# Altium schematic sheet 08_SOM_USB_CARD.SchDoc(08_SOM_USB_CARD)
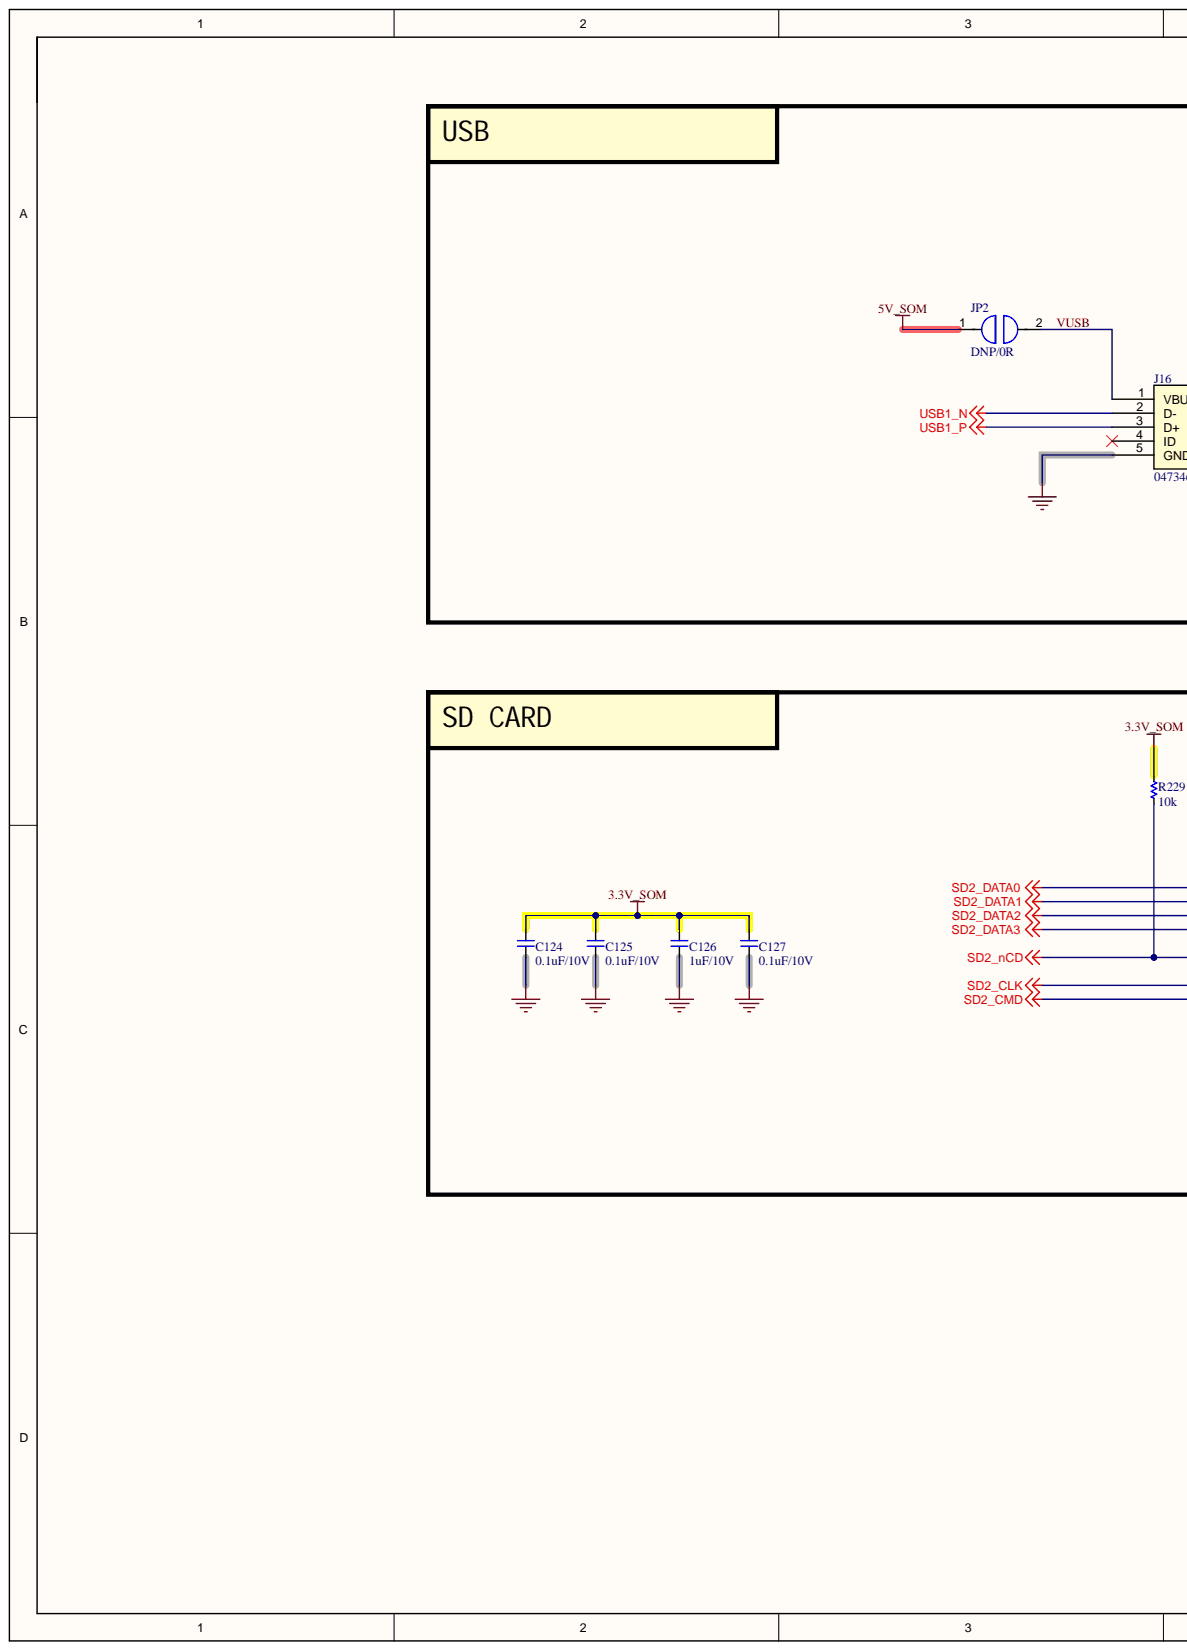
[diagram p0: 08_SOM_USB_CARD.SchDoc, left]
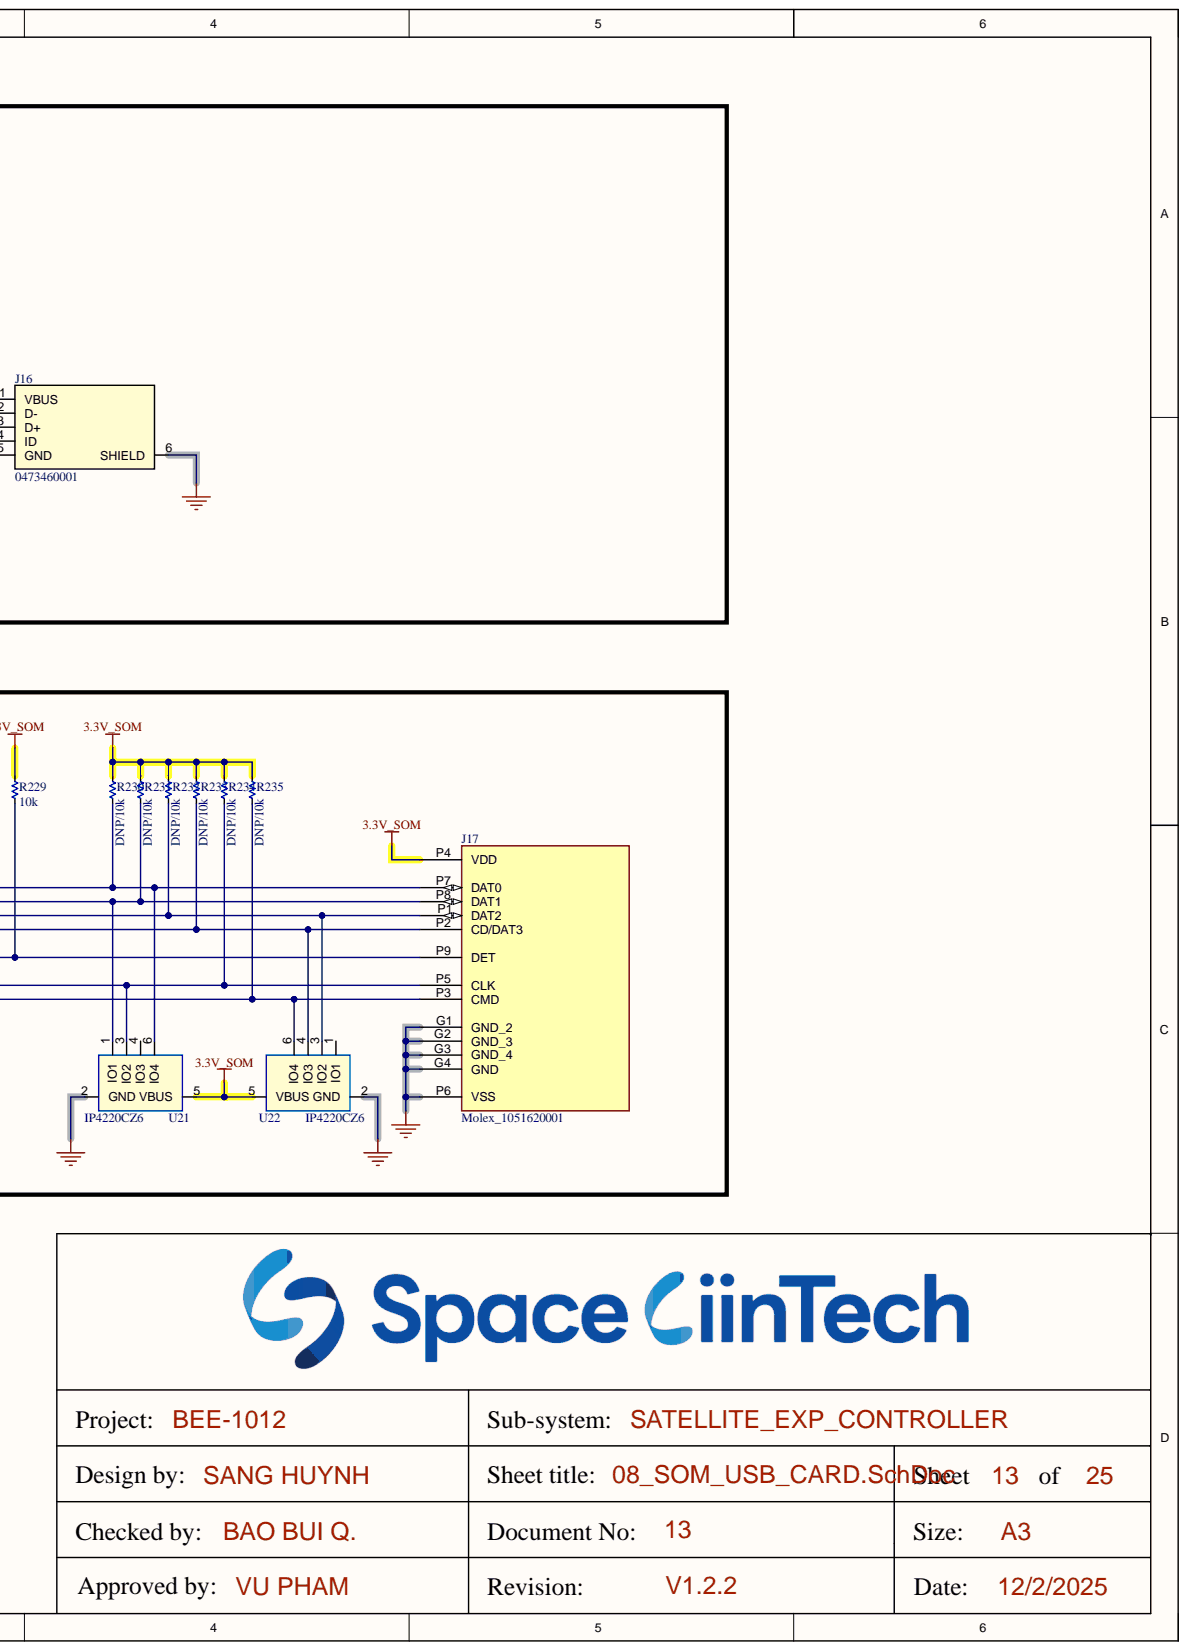
[diagram p1: 08_SOM_USB_CARD.SchDoc, right]

## Parts
C124  0.1uF/10V  [CAP_C_1005X60]
C125  0.1uF/10V  [CAP_C_1005X60]
C126  1uF/10V  [CAP_C_1005X60]
C127  0.1uF/10V  [CAP_C_1005X60]
J16  0473460001  [47346-0001_MOL]
J17  Molex_1051620001  [Molex_1051620001]
JP2  DNP/0R  [RES_C_1608X60]
R229  10k  [RES_C_1005X40]
R230  DNP/10k  [RES_C_1005X40]
R231  DNP/10k  [RES_C_1005X40]
R232  DNP/10k  [RES_C_1005X40]
R233  DNP/10k  [RES_C_1005X40]
R234  DNP/10k  [RES_C_1005X40]
R235  DNP/10k  [RES_C_1005X40]
U21  IP4220CZ6  [SOT95P280X145-6N]
U22  IP4220CZ6  [SOT95P280X145-6N]

## Nets
3.3V_SOM: C124.2 C125.2 C126.2 C127.2 J17.P4(VDD) R229.2 R230.2 R231.2 R232.2 R233.2 R234.2 R235.2 U21.5(VBUS) U22.5(VBUS)
5V_SOM: JP2.1
GND: C124.1 C125.1 C126.1 C127.1 J16.5(GND) J16.6(SHIELD) J17.G1(GND_2) J17.G2(GND_3) J17.G3(GND_4) J17.G4(GND) J17.P6(VSS) U21.2(GND) U22.2(GND)
SD2_CLK: J17.P5(CLK) R234.1 U21.3(IO2)
SD2_CMD: J17.P3(CMD) R235.1 U22.6(IO4)
SD2_DATA0: J17.P7(DAT0) R230.1 U21.6(IO4)
SD2_DATA1: J17.P8(DAT1) R231.1 U21.1(IO1)
SD2_DATA2: J17.P1(DAT2) R232.1 U22.3(IO2)
SD2_DATA3: J17.P2(CD/DAT3) R233.1 U22.4(IO3)
SD2_nCD: J17.P9(DET) R229.1
USB1_N: J16.2(D-)
USB1_P: J16.3(D+)
VUSB: J16.1(VBUS) JP2.2

Not connected: J16 4(ID); U21 4(IO3); U22 1(IO1)

## Library data of the parts
C124-C127 = _CAP_C_2: CAP CHIP; Component Kind: Standard; Library Name: LABLIB.SchLib
J16 = USB_micro: No Description Available; Mfr_Name: Molex Connector Corporation; Component Kind: Standard; RefDes: RefDes; Type: Type; Library Name: obc_ver2.SCHLIB
J17 = Molex_1051620001: 9 (8 + 1) Position Card Connector Secure Digital - microSDâ„¢ Surface Mount, Right Angle Gold; MF: Molex; Check_prices: https://www.snapeda.com/parts/1051620001/Molex/view-part/?ref=eda; Component Kind: Standard; Library Name: new_component.SchLib; MP: 1051620001; Package: None; SnapEDA_Link: https://www.snapeda.com/parts/1051620001/Molex/view-part/?ref=snap
JP2 = JUMPER: Component Kind: Standard; Library Name: EXP_V1.SchLib
R229-R235 = _RES_B_2: RES; Component Kind: Standard; Library Name: LABLIB.SchLib
U21 U22 = IP4220CZ6_0: CLABCONF0: #NAME?; P/N: 132D42200S; PCB Footprint: SOT23-6L; Component Kind: Standard
